# Altium schematic sheet Microcontroller.SchDoc(Microcontroller)
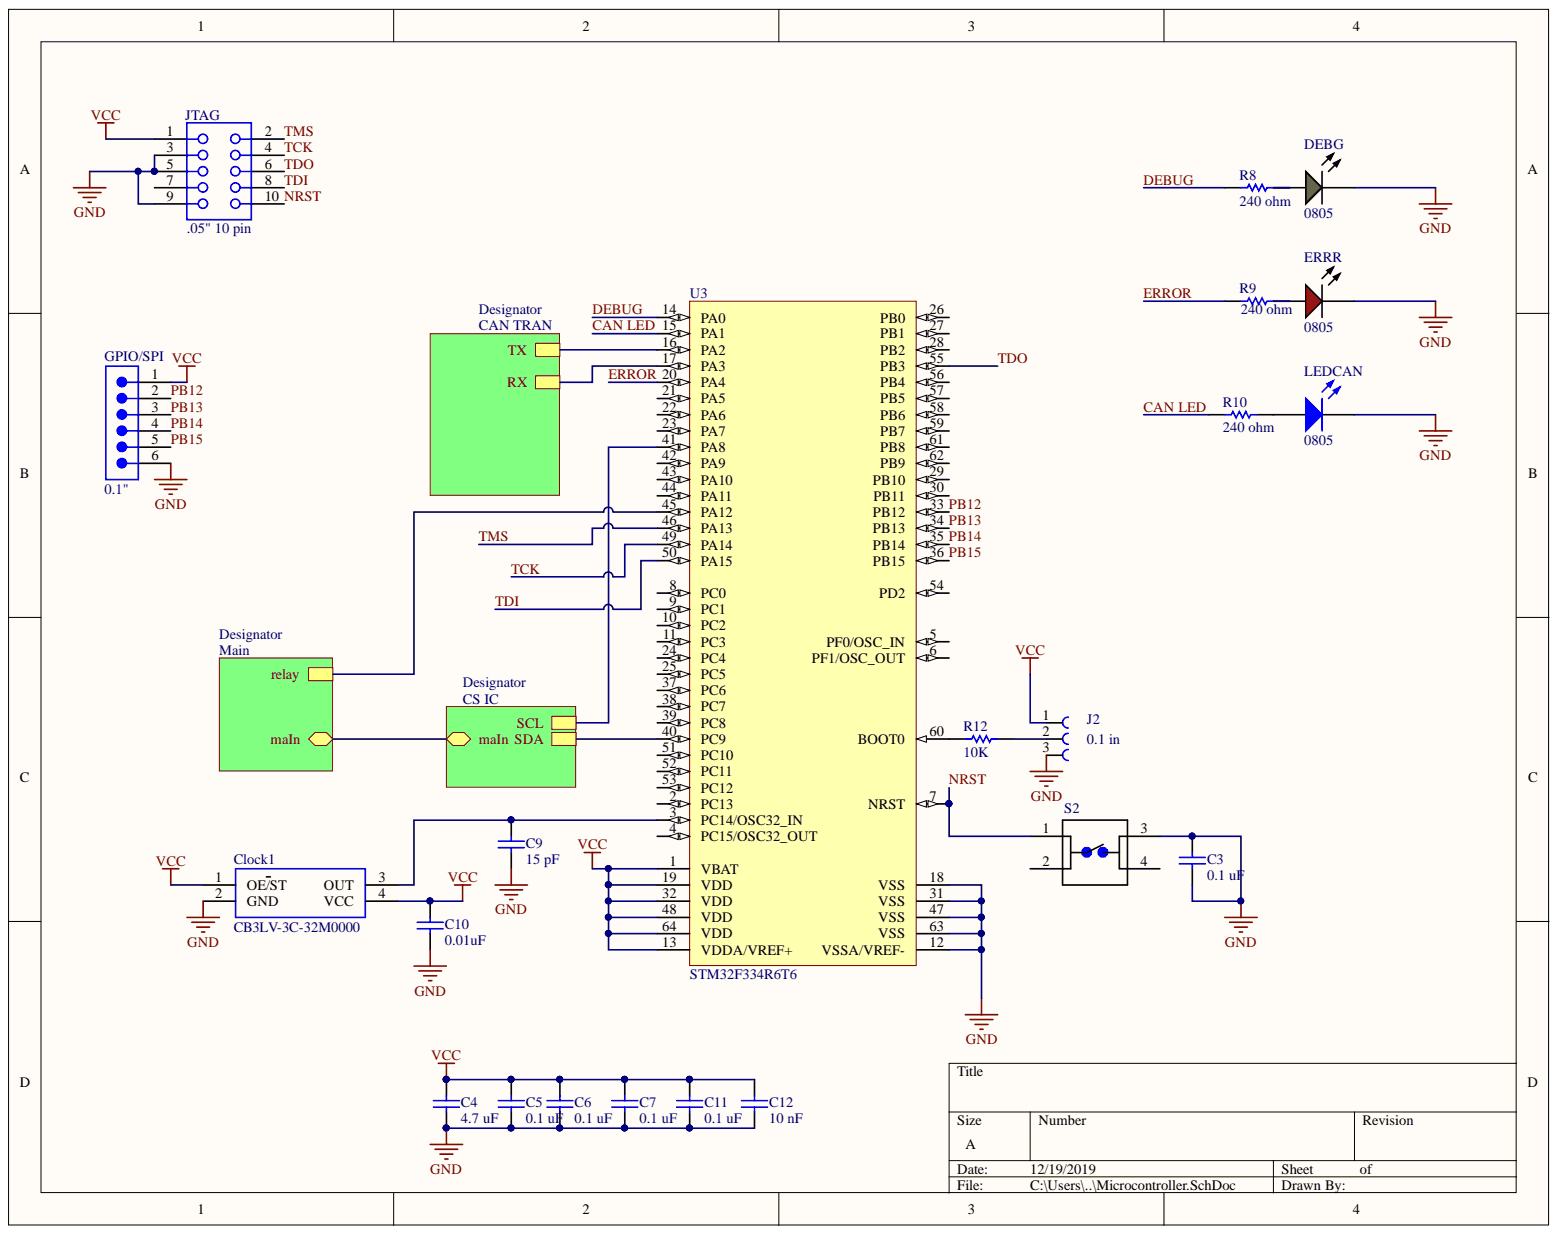
[diagram p0: Microcontroller.SchDoc]

## Parts
C3  0.1 uF  [CAPC0805(2012)130_N]
C4  4.7 uF  [CAPC0805(2012)130_N]
C5  0.1 uF  [CAPC0805(2012)130_N]
C6  0.1 uF  [CAPC0805(2012)130_N]
C7  0.1 uF  [CAPC0805(2012)130_N]
C9  15 pF  [CAPC0805(2012)130_N]
C10  0.01uF  [CAPC0805(2012)130_N]
C11  0.1 uF  [CAPC0805(2012)130_N]
C12  10 nF  [CAPC0805(2012)130_N]
Clock1  CB3LV-3C-32M0000  [SG-636]
DEBG  0805  [LUMX-SML-LXT0805_V]
ERRR  0805  [LTST-C170KRKT_V]
GPIO/SPI  0.1"  [SMTC-TSW-106-23-X-S]
J2  0.1 in  [61300311121]
JTAG  .05" 10 pin  [PCE-FH127H432-10-SNGRH-2.4]
LEDCAN  0805  [0805_A]
R8  240 ohm  [RESC0805(2012)_N]
R9  240 ohm  [RESC0805(2012)_N]
R10  240 ohm  [RESC0805(2012)_N]
R12  10K  [RESC0805(2012)_N]
S2  1825910-7  [*SW_1825910-7]  (value not drawn)
U3  STM32F334R6T6  [STM-LQFP64_N]

## Nets
GND: C3.1 C3.2 C4.1 C5.1 C6.1 C7.1 C9.1 C10.1 C11.1 C12.1 Clock1.2(GND) DEBG.2 ERRR.2 GPIO/SPI.6 J2.3 JTAG.3 JTAG.5 JTAG.9 LEDCAN.1 S2.3 U3.12(VSSA/VREF-) U3.18(VSS) U3.31(VSS) U3.47(VSS) U3.63(VSS)
VCC: C4.2 C5.2 C6.2 C7.2 C10.2 C11.2 C12.2 Clock1.1(OE/ST) Clock1.4(VCC) GPIO/SPI.1 J2.1 JTAG.1 U3.1(VBAT) U3.13(VDDA/VREF+) U3.19(VDD) U3.32(VDD) U3.48(VDD) U3.64(VDD)
CAN LED: R10.1 U3.15(PA1)
DEBUG: R8.1 U3.14(PA0)
ERROR: R9.2 U3.20(PA4)
NRST: JTAG.10 S2.1 U3.7(NRST)
PB12: GPIO/SPI.2 U3.33(PB12)
PB13: GPIO/SPI.3 U3.34(PB13)
PB14: GPIO/SPI.4 U3.35(PB14)
PB15: GPIO/SPI.5 U3.36(PB15)
TCK: JTAG.4 U3.49(PA14)
TDI: JTAG.8 U3.50(PA15)
TDO: JTAG.6 U3.55(PB3)
TMS: JTAG.2 U3.46(PA13)
NetC9_2: C9.2 Clock1.3(OUT) U3.3(PC14/OSC32_IN)
NetDEBG_1: DEBG.1 R8.2
NetERRR_1: ERRR.1 R9.1
NetJ2_2: J2.2 R12.1
NetJTAG_7: JTAG.7
NetLEDCAN_2: LEDCAN.2 R10.2
NetR12_2: R12.2 U3.60(BOOT0)
NetS2_2: S2.2
NetS2_4: S2.4
NetU3_2: U3.2(PC13)
NetU3_4: U3.4(PC15/OSC32_OUT)
NetU3_5: U3.5(PF0/OSC_IN)
NetU3_6: U3.6(PF1/OSC_OUT)
NetU3_8: U3.8(PC0)
NetU3_9: U3.9(PC1)
NetU3_10: U3.10(PC2)
NetU3_11: U3.11(PC3)
NetU3_16: U3.16(PA2)
NetU3_17: U3.17(PA3)
NetU3_21: U3.21(PA5)
NetU3_22: U3.22(PA6)
NetU3_23: U3.23(PA7)
NetU3_24: U3.24(PC4)
NetU3_25: U3.25(PC5)
NetU3_26: U3.26(PB0)
NetU3_27: U3.27(PB1)
NetU3_28: U3.28(PB2)
NetU3_29: U3.29(PB10)
NetU3_30: U3.30(PB11)
NetU3_37: U3.37(PC6)
NetU3_38: U3.38(PC7)
NetU3_39: U3.39(PC8)
NetU3_40: U3.40(PC9)
NetU3_41: U3.41(PA8)
NetU3_42: U3.42(PA9)
NetU3_43: U3.43(PA10)
NetU3_44: U3.44(PA11)
NetU3_45: U3.45(PA12)
NetU3_51: U3.51(PC10)
NetU3_52: U3.52(PC11)
NetU3_53: U3.53(PC12)
NetU3_54: U3.54(PD2)
NetU3_56: U3.56(PB4)
NetU3_57: U3.57(PB5)
NetU3_58: U3.58(PB6)
NetU3_59: U3.59(PB7)
NetU3_61: U3.61(PB8)
NetU3_62: U3.62(PB9)
maIn:

## Library data of the parts
C3-C7 C9-C12 = CMP-1036-02603-1: CAP 390pF 200V ±5% 0805 (2012 Metric) Thickness 1.3mm SMD; Tolerance: Â±5%; Case-Metric: 2012; Case-EIA: 0805; Value: 390pF; Technology: SMT; Rated Voltage: 200 V; Max Thickness: 1.3 mm; Library Name: Altium Content Vault; Component Kind: Standard
Clock1 = SG-636: Standard Clock Oscillators 50MHz 3.3Volt 100ppm -20C +70C; Manufacturer_Part_Number: SG-636PCW 50.0000MC3: ROHS; Manufacturer_Name: Epson Timing; Library Name: Oscillator.SchLib; Datasheet Link: http://www.mouser.com/ds/2/137/G-636PCE_en-1003252.pdf; ComponentLink1URL: https://www.digikey.com/product-detail/en/abracon-llc/ASE-32.000MHZ-ET/535-9990-1-ND/2001613; ComponentLink1Description: datasheet; Component Kind: Standard; Arrow Part Number: SG-636PCW 50.0000MC3: ROHS
DEBG = CMP-1724-00001-1: LED, GREEN, 565 nm, 2 V, -25 to 80 degC, 2-Pin SMD, RoHS, Tape and Reel; Width: 1.25 mm; RoHSCompliant: true; REACH SVHC: No SVHC; Packaging: Tape and Reel; PackageReference: SML-LXT0805; Package Quantity: 4000; PackageDescription: 2-Pin Surface Mount Device, Body 2 x 1.25 mm; Mounting Technology: Surface Mount; Mount: Surface Mount; Min Operating Temperature: -25 degC; Max Operating Temperature: 85 degC; Library Name: Altium Content Vault; Length: 2 mm; DatasheetVersion: Rev. F, 09/2009; ComponentLink2URL: http://www.lumex.com/content/files/ProductAttachment/SML-LXT0805GW-TR.pdf; ComponentLink2Description: Datasheet; ComponentLink1URL: http://www.lumexinstruments.com/; ComponentLink1Description: Manufacturer URL; Component Kind: Standard; Case Code (Metric): 2012; Case Code (Imperial): 0805; Case\/Package: 0805
ERRR = CMP-2000-07593-1: LED Red, 75 mW, 54 mcd, -55 to 85 degC, 2-Pin SMD, RoHS, Tape and Reel; Manufacturer: Lite-On; Wavelength: 639nm; Viewing Angle: 130degrees; Test Current: 20mA; Power Rating: 75mW; Number of LEDs: 1; Luminous Intensity: 54mcd; Lens Transparency: Clear; Lens Style: Rectangular; Lens Colour: Colorless; Forward Voltage: 2V; Forward Current: 30mA; Dominant Wavelength: 631nm; Width: 1.25mm; RoHSCompliant: True; Radiation Hardening: No; Power Dissipation: 75mW; Packaging: TapeandReel; Package Reference: C170KRKT; Package Quantity: 1; Package Description: 2-Pin Surface Mount Device, Body 2 x 1.25 mm; Number of Pins: 2; Number of Elements: 1; Mounting Technology: SurfaceMount; Mount: SurfaceMount; Min Operating Temperature: -55degC; Max Operating Temperature: 85degC; Manufacturer URL: http://www.us.liteon.com/; Library Name: Altium Content Vault; Length: 3.2mm; Lead Free: LeadFree; Illumination Colour: Red; Height: 1.1mm; Depth: 1.6mm; Datasheet Version: Rev. D, 11/2014; Datasheet URL: http://optoelectronics.liteon.com/upload/download/DS-22-99-0150/LTST-C170KRKT.pdf; Component Kind: Standard; Colour: Red; Case Code (Metric): 2012; Case Code (Imperial): 0805; Case\/Package: 0805
GPIO/SPI = CMP-1024-00395-1: 0.025" SQ Post Header, Through-hole, Vertical, -55 to 105 degC, 2.54 mm Pitch, 6-Pin, Male, RoHS; PartNumber: TSW-106-23-T-S; Manufacturer: Samtec; Pitch: 2.54 mm; Temperature Range: -55 to 105 degC; RoHS: True; PackageVersion: DM, 8/1988; PackageReference: TSW-106-23-X-S; PackageDescription: .025[0.64] SQ. Post Terminal Strip Assembly; Orientation: Vertical; Mounting Technology: Through-hole; Library Name: Altium Content Vault; DatasheetVersion: F-214; ComponentLink3URL: http://www.samtec.com/documents/webfiles/cpdf/TSW-XXX-XX-XXX-X-XX-XXX-MKT.pdf; ComponentLink3Description: Package Specification; ComponentLink2URL: http://www.samtec.com/documents/webfiles/pdf/TSW_TH.PDF; ComponentLink2Description: Datasheet; ComponentLink1URL: http://www.samtec.com; ComponentLink1Description: Manufacturer URL; Component Kind: Standard
J2 = 61300311121: Board-To-Board Connector, Vertical, WR-PHD Series, Through Hole, Header, 3, 2.54 mm; MF: Wurth Electronics; SnapEDA_Link: https://www.snapeda.com/parts/61300311121/Wurth%20Electronics%20Inc./view-part/?ref=snap; Price: None; Package: None; MP: 61300311121; Library Name: 61300311121.IntLib; Component Kind: Standard; Availability: Unavailable
JTAG = CMP-0594-00001-1: Female Header, Pitch 1.27 mm, 2x5 Position, Height 4.3 mm, Tail Length 2.4 mm; PartNumber: FH127H432-10-SNGRH-2.4; Manufacturer: Pinchao Electronic; Plating: Gold Flash; Pitch: 1.27 mm; No. of Rows: 2; No. of positions: 10; Insulator resistance (Min.): 5000 Megohms; Insulator Material: NY-6T; Dielectric Withstaanding: AC 500 V; Current Rating: 2 Amps; PackageReference: FH127H432-10-SNGRH-2.4; PackageDescription: Female Header, Pitch 1.27 mm, 2x5 Position, Height 4.3 mm, Tail Length 2.4 mm; Operating Temperature: -40 to 105 degC; Mounting Technology: Through Hole; Library Name: Altium Content Vault; Connector Type: Female Header; ComponentLink1URL: http://www.suzhoupinchao.com/; ComponentLink1Description: Manufacturer URL; Component Kind: Standard
LEDCAN = CMP-1426-00009-1: SMD mono-color Chip LED, WL-SMCW, Bright Green; PartNumber: 150080VS75000; Manufacturer: Wuerth Elektronik; PackageReference: 0805_A; Library Name: Altium Content Vault; ComponentLink2URL: http://katalog.we-online.com/led/datasheet/150080VS75000.pdf; ComponentLink2Description: Datasheet; ComponentLink1URL: http://www.we-online.com; ComponentLink1Description: Manufacturer URL; Component Kind: Standard; Color: Bright Green
R8-R10 R12 = CMP-1013-00418-1: 160R 0.125W 1% 0805 (2012 Metric)  SMD; Value: 160.00 Ohm; Case-Metric: 2012; Case-EIA: 0805; Tolerance: 1 %; Technology: SMT; Power: 0.125 W; Library Name: Altium Content Vault; Component Kind: Standard
S2 = 1825910-7: FSM4JAH=6MM TACT SWITCH, HIGH TEMP; MF: TE Connectivity; Contact_Current_Rating: 50 mA; Configuration_Pole-Throw: Single Pole - Single Throw; TE_purchase_URL: https://www.te.com/usa-en/product-1825910-7.html?te_bu=Cor&te_type=disp&te_campaign=seda_glo_cor-seda-global-disp-prtnr-fy19-seda-model-bom-cta_sma-317_1&elqCampaignId=32493; SnapEDA_Link: https://www.snapeda.com/parts/1825910-7/TE%20Connectivity%20ALCOSWITCH%20Switches/view-part/?ref=snap; Price: None; Package: None; MP: 1825910-7; Library Name: 1825910-7.IntLib; EU_RoHS_Compliance: Compliant; Component Kind: Standard; Availability: Unavailable
U3 = STM32F334R6T6: ARM Cortex-M4 32-bit MCU, 64-Pin LQFP, 32 KB Flash, 16 KB Internal RAM, 51 I/Os, -40 to 85 degC, Tray; Library Name: STM32F334 (1).SchLib; Component Kind: Standard
